# Altium schematic sheet int_logic.SchDoc
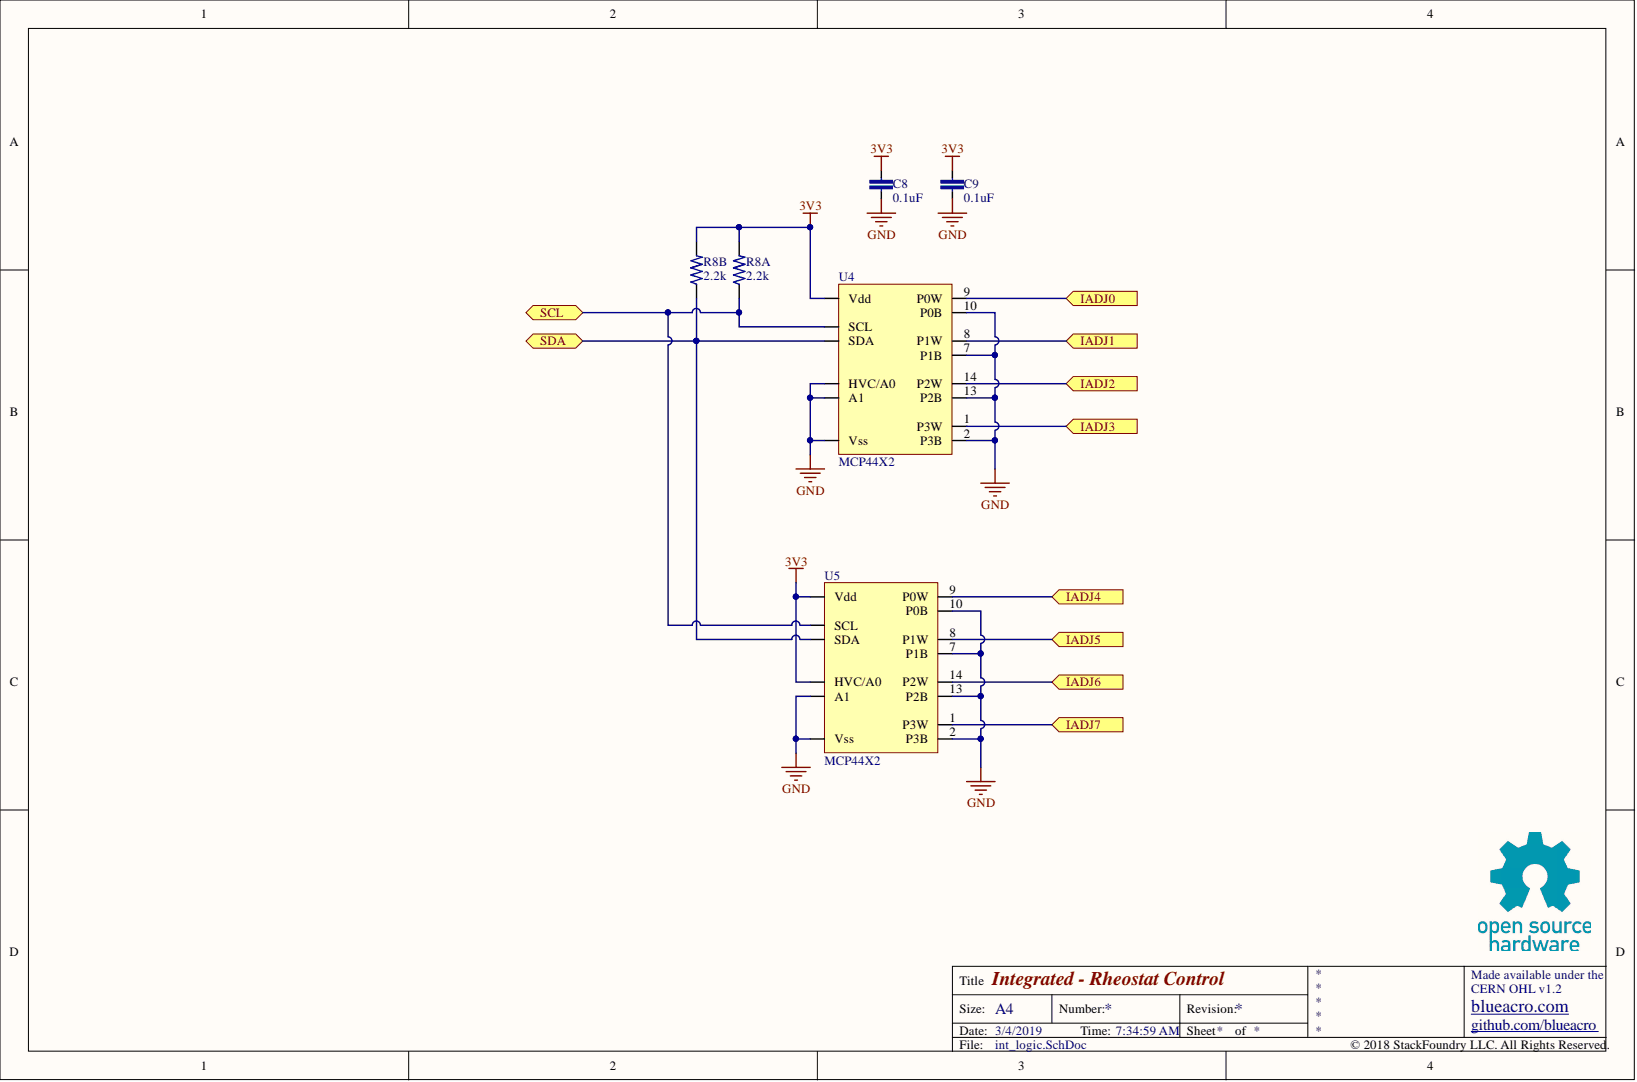
[diagram p0: int_logic.SchDoc]

## Parts
C8  0.1uF  [C0603]
C9  0.1uF  [C0603]
R8  2.2k  [R0603x4]  (parts A,B)
U4  MCP44X2  [TSSOP14]
U5  MCP44X2  [TSSOP14]

## Ports
IADJ0, IADJ1, IADJ2, IADJ3, IADJ4, IADJ5, IADJ6, IADJ7, SCL, SDA

## Nets
3V3: C8.1 C9.1 R8.2 R8.4 U4.12(Vdd) U5.3(HVC/A0) U5.12(Vdd)
GND: C8.2 C9.2 U4.2(P3B) U4.3(HVC/A0) U4.6(Vss) U4.7(P1B) U4.10(P0B) U4.11(A1) U4.13(P2B) U5.2(P3B) U5.6(Vss) U5.7(P1B) U5.10(P0B) U5.11(A1) U5.13(P2B)
IADJ0: U4.9(P0W) ; port IADJ0
IADJ1: U4.8(P1W) ; port IADJ1
IADJ2: U4.14(P2W) ; port IADJ2
IADJ3: U4.1(P3W) ; port IADJ3
IADJ4: U5.9(P0W) ; port IADJ4
IADJ5: U5.8(P1W) ; port IADJ5
IADJ6: U5.14(P2W) ; port IADJ6
IADJ7: U5.1(P3W) ; port IADJ7
SCL: R8.1 U4.4(SCL) U5.4(SCL) ; port SCL
SDA: R8.3 U4.5(SDA) U5.5(SDA) ; port SDA

## Library data of the parts
C8 C9 = C-EU: Component Kind: Standard; Library Name: rcl.IntLib
R8 = R-US-4ch: Component Kind: Standard; Library Name: rcl.IntLib
U4 U5 = MCP44X2: Component Kind: Standard; Library Name: microchip.IntLib
